# Altium schematic sheet MultiCharge2.SchDoc
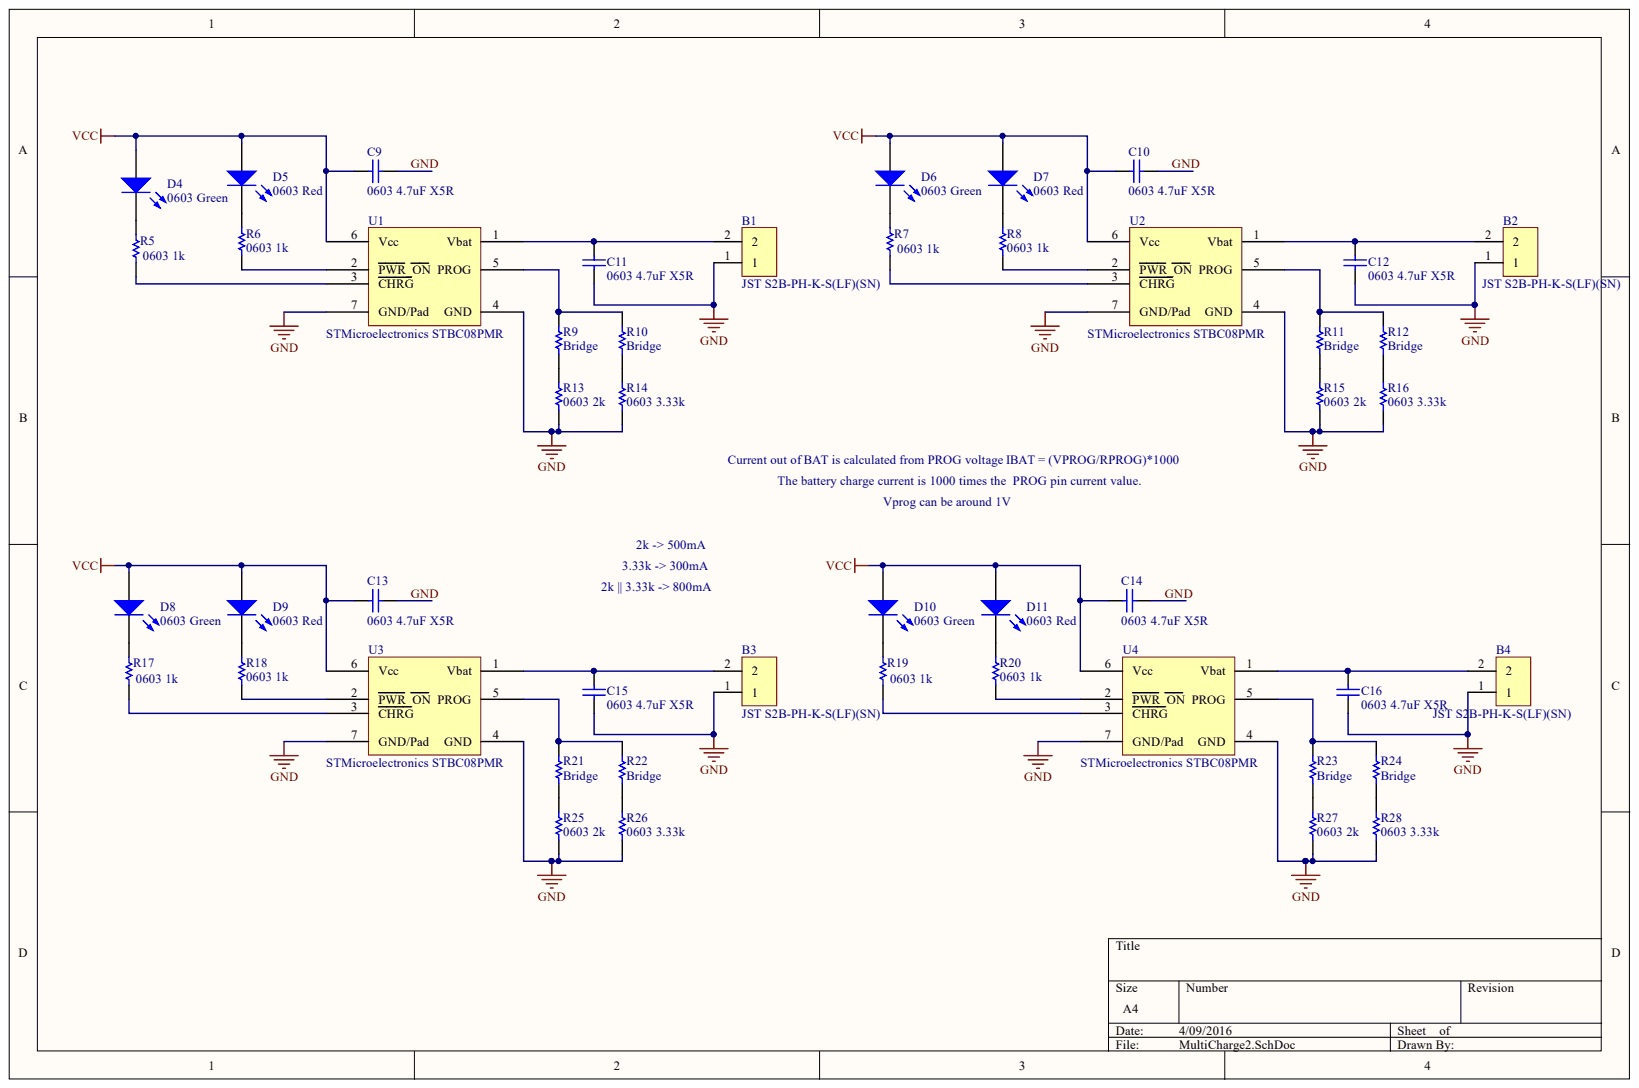
[diagram p0: MultiCharge2.SchDoc]

## Parts
B1  JST S2B-PH-K-S(LF)(SN)  [JST S2B-PH-K-S]
B2  JST S2B-PH-K-S(LF)(SN)  [JST S2B-PH-K-S]
B3  JST S2B-PH-K-S(LF)(SN)  [JST S2B-PH-K-S]
B4  JST S2B-PH-K-S(LF)(SN)  [JST S2B-PH-K-S]
C9  0603 4.7uF X5R  [CAPC1608X90X35ML10T15]
C10  0603 4.7uF X5R  [CAPC1608X90X35ML10T15]
C11  0603 4.7uF X5R  [CAPC1608X90X35ML10T15]
C12  0603 4.7uF X5R  [CAPC1608X90X35ML10T15]
C13  0603 4.7uF X5R  [CAPC1608X90X35ML10T15]
C14  0603 4.7uF X5R  [CAPC1608X90X35ML10T15]
C15  0603 4.7uF X5R  [CAPC1608X90X35ML10T15]
C16  0603 4.7uF X5R  [CAPC1608X90X35ML10T15]
D4  0603 Green  Case/Package: 0603  [LED1608x55N]
D5  0603 Red  Case/Package: 0603  [LED1608x55N]
D6  0603 Green  Case/Package: 0603  [LED1608x55N]
D7  0603 Red  Case/Package: 0603  [LED1608x55N]
D8  0603 Green  Case/Package: 0603  [LED1608x55N]
D9  0603 Red  Case/Package: 0603  [LED1608x55N]
D10  0603 Green  Case/Package: 0603  [LED1608x55N]
D11  0603 Red  Case/Package: 0603  [LED1608x55N]
R5  0603 1k  [RESC1608X55X30ML15T15]
R6  0603 1k  [RESC1608X55X30ML15T15]
R7  0603 1k  [RESC1608X55X30ML15T15]
R8  0603 1k  [RESC1608X55X30ML15T15]
R9  Bridge  [RESC1005X40X25NL05T05]
R10  Bridge  [RESC1005X40X25NL05T05]
R11  Bridge  [RESC1005X40X25NL05T05]
R12  Bridge  [RESC1005X40X25NL05T05]
R13  0603 2k  Case/Package: 0603  [RESC1609X50X30ML10T20]
R14  0603 3.33k  Case/Package: 0603  [RESC1608X55X30ML15T15]
R15  0603 2k  Case/Package: 0603  [RESC1609X50X30ML10T20]
R16  0603 3.33k  Case/Package: 0603  [RESC1608X55X30ML15T15]
R17  0603 1k  [RESC1608X55X30ML15T15]
R18  0603 1k  [RESC1608X55X30ML15T15]
R19  0603 1k  [RESC1608X55X30ML15T15]
R20  0603 1k  [RESC1608X55X30ML15T15]
R21  Bridge  [RESC1005X40X25NL05T05]
R22  Bridge  [RESC1005X40X25NL05T05]
R23  Bridge  [RESC1005X40X25NL05T05]
R24  Bridge  [RESC1005X40X25NL05T05]
R25  0603 2k  Case/Package: 0603  [RESC1609X50X30ML10T20]
R26  0603 3.33k  Case/Package: 0603  [RESC1608X55X30ML15T15]
R27  0603 2k  Case/Package: 0603  [RESC1609X50X30ML10T20]
R28  0603 3.33k  Case/Package: 0603  [RESC1608X55X30ML15T15]
U1  STMicroelectronics STBC08PMR  Manufacturer: STMicroelectronics  Manufacturer Part Number: STBC08PMR  [PCB-aislhniljlol1jo7ju5i-1]
U2  STMicroelectronics STBC08PMR  Manufacturer: STMicroelectronics  Manufacturer Part Number: STBC08PMR  [PCB-aislhniljlol1jo7ju5i-1]
U3  STMicroelectronics STBC08PMR  Manufacturer: STMicroelectronics  Manufacturer Part Number: STBC08PMR  [PCB-aislhniljlol1jo7ju5i-1]
U4  STMicroelectronics STBC08PMR  Manufacturer: STMicroelectronics  Manufacturer Part Number: STBC08PMR  [PCB-aislhniljlol1jo7ju5i-1]

## Nets
VCC: C9.2 C10.2 C13.2 C14.2 D4.1 D5.1 D6.1 D7.1 D8.1 D9.1 D10.1 D11.1 U1.6(Vcc) U2.6(Vcc) U3.6(Vcc) U4.6(Vcc)
GND: B1.1 B2.1 B3.1 B4.1 C9.1 C10.1 C11.1 C12.1 C13.1 C14.1 C15.1 C16.1 R13.1 R14.1 R15.1 R16.1 R25.1 R26.1 R27.1 R28.1 U1.4(GND) U1.7(GND/Pad) U2.4(GND) U2.7(GND/Pad) U3.4(GND) U3.7(GND/Pad) U4.4(GND) U4.7(GND/Pad)
NetB1_2: B1.2 C11.2 U1.1(Vbat)
NetB2_2: B2.2 C12.2 U2.1(Vbat)
NetB3_2: B3.2 C15.2 U3.1(Vbat)
NetB4_2: B4.2 C16.2 U4.1(Vbat)
NetD4_2: D4.2 R5.2
NetD5_2: D5.2 R6.2
NetD6_2: D6.2 R7.2
NetD7_2: D7.2 R8.2
NetD8_2: D8.2 R17.2
NetD9_2: D9.2 R18.2
NetD10_2: D10.2 R19.2
NetD11_2: D11.2 R20.2
NetR5_1: R5.1 U1.3(CHRG)
NetR6_1: R6.1 U1.2(PWR_ON)
NetR7_1: R7.1 U2.3(CHRG)
NetR8_1: R8.1 U2.2(PWR_ON)
NetR9_1: R9.1 R13.2
NetR9_2: R9.2 R10.2 U1.5(PROG)
NetR10_1: R10.1 R14.2
NetR11_1: R11.1 R15.2
NetR11_2: R11.2 R12.2 U2.5(PROG)
NetR12_1: R12.1 R16.2
NetR17_1: R17.1 U3.3(CHRG)
NetR18_1: R18.1 U3.2(PWR_ON)
NetR19_1: R19.1 U4.3(CHRG)
NetR20_1: R20.1 U4.2(PWR_ON)
NetR21_1: R21.1 R25.2
NetR21_2: R21.2 R22.2 U3.5(PROG)
NetR22_1: R22.1 R26.2
NetR23_1: R23.1 R27.2
NetR23_2: R23.2 R24.2 U4.5(PROG)
NetR24_1: R24.1 R28.2

## Library data of the parts
B1-B4 = CMP-642951-6: CONN HEADER PH SIDE 2POS 2MM; Gender: Male; Lead-Free Status: Lead Free; Number of Contacts: 2; Pin Pitch: 0.002 m; Component Kind: Standard; Contact Material: Brass; Contact Plating: Tin; Housing Color: Natural; Housing Material: Nylon; Library Name: CommunityVault; Mounting Style: Through Hole; Number of Positions: 2; Number of Rows: 1; OctopartID: 84064d0f6d535bc1; Octopart Url: https://octopart.com/s2b-ph-k-s%28lf%29%28sn%29-jst-248883; Packaging: Bulk; RoHS: Compliant; Size-Height: 0.0048 m; Size-Length: 0.0059 m; Size-Width: 0.0076 m
C9-C16 = CMP-9133140-3: Cap Ceramic 4.7uF 10V X5R 10% SMD 0603 85C Paper T/R; Capacitance: 4.7e-06 F; Case/Package (SI): 1608; Voltage Rating (DC): 10.0 V; Capacitance Tolerance: ±10%; Component Kind: Standard; Dielectric Characteristic: X5R; Library Name: CommunityVault; Lifecycle Status: Active; OctopartID: f5ad3de176fc2a6a; Octopart Url: https://octopart.com/grm188r61a475ke15d-murata-21407287; Packaging: Cut Tape (CT); REACH SVHC Compliance: No SVHC; RoHS: Compliant; Size-Length: 0.0016 m; Size-Thickness: 0.0008 m; Size-Width: 0.0008 m
D4 D6 D8 D10 = CMP-1544853-3: Green 1.6 x 0.8 x 0.8 mm 130° Water Clear 6 mcd 2.1 V SMT LED; Manufacturer Part Number: LTST-C190GKT; Forward Voltage: 2.1 V; Lead-Free Status: Lead Free; Lens Type: Non-Diffused; Luminous Intensity: 0.006 cd; Wavelength: 5.65e-07 m; Color: Green; Component Kind: Standard; Library Name: CommunityVault; Mounting Style: Surface Mount, Vertical; OctopartID: b2f07bf2f42b6d37; Octopart URL: http://octopart.com/ltst-c190gkt-lite-on-549821; Packaging: Tape & Reel (TR); RoHS: Compliant; Size-Height: 0.0008 m; Size-Length: 0.0016 m; Size-Width: 0.0008 m; Viewing Angle: 130 °
D5 D7 D9 D11 = CMP-1544848-3: LED SUPER RED CLR THIN 0603 SMD; Forward Voltage: 2.0 V; Lead-Free Status: Lead Free; Lens Type: Non-Diffused; Luminous Intensity: 0.054 cd; Viewing Angle: 130 °; Wavelength: 6.39e-07 m; Color: Red; Component Kind: Standard; Library Name: CommunityVault; OctopartID: 4ddf84dd0cad9218; Octopart Url: https://octopart.com/ltst-c191krkt-lite-on-549908; RoHS: Compliant; Size-Height: 0.00055 m; Size-Length: 0.0016 m; Size-Width: 0.0008 m
R5-R8 R17-R20 = CMP-3654795-5: Precision resistor, SMD [Panasonic Automotive & Industrial Systems] ERJ3EKF1001V Precision resistor, SMD; Manufacturer Part Number: ERJ-3EKF1001V; Power Rating: 100 mW; Resistance Tolerance: ±1%; Component Kind: Standard; Library Name: CommunityVault; Number of Pins: 2; Octopart URL: http://octopart.com/erj-3ekf1001v-panasonic-55403646; Size-Height: 450 µm
R9-R12 R21-R24 = CMP-15792799-5: Bridge resistor 0ohm; Lead-Free Status: Lead Free; Power Rating: 0.063 W; Resistance: 0.0 Ω; Resistance Tolerance: ±1%; Voltage Rating (DC): 50.0 V; Case/Package: 0402; Component Kind: Standard; Library Name: CommunityVault; Mounting Style: Surface Mount; Number of Pins: 2; OctopartID: fdb336e0639f2274; Octopart Url: https://octopart.com/crcw04020000z0ed-vishay-39435082; REACH SVHC Compliance: No SVHC; RoHS: Compliant; Size-Height: 0.00035 m; Size-Length: 0.001 m; Size-Width: 0.0005 m
R13 R15 R25 R27 = CMP-1877180-2: RES SMD 2K OHM 5% 1/10W 0603; Lead-Free Status: Lead Free; Power Rating: 0.1 W; Resistance: 2000.0 Ω; Temperature Coefficient: 200.0 ppm/°C; Voltage Rating (DC): 75.0 V; Component Kind: Standard; Library Name: CommunityVault; Mounting Style: Surface Mount; Number of Pins: 2; OctopartID: d88b13de5f6d074c; Octopart Url: https://octopart.com/crcw06032k00jnea-vishay-39821952; Resistance Tolerance: ±5%; RoHS: Compliant; Size-Height: 0.00045 m; Size-Length: 0.00155 m; Size-Width: 0.00085 m
R14 R16 R26 R28 = CMP-3656259-2: Thick Film Resistors - SMD 0603 3.3Kohms 5% Tol; Lead-Free Status: Lead Free; Power Rating: 0.1 W; Resistance: 3300.0 Ω; Voltage Rating (DC): 75.0 V; Component Kind: Standard; Library Name: CommunityVault; Lifecycle Status: Not Listed by Manufacturer; Mounting Style: Surface Mount; OctopartID: f2c038877b272d07; Octopart Url: https://octopart.com/erj-3geyj332v-panasonic-55422081; Packaging: Cut Tape (CT); REACH SVHC Compliance: No SVHC; Resistance Tolerance: ±5%; RoHS: Compliant; Size-Height: 0.00045 m; Size-Length: 0.0016 m; Size-Width: 0.0008 m
U1-U4 = CMP-facc33d44885250b-1: IC BATT CHRGR LI-ION 4.2V 800MA DFN6; Manufacturer Part Number, Other: 497-5808-1; Vendor Part Number: 497-5808-1-ND; Function: Charge Management; Voltage - Supply: 4.25 V ~ 6.5 V; Battery Chemistry: Li-Ion; Category: Integrated Circuits (ICs); Component Kind: Standard; Family: PMIC - Battery Management; HelpURL: http://www2.st.com/content/ccc/resource/technical/document/datasheet/32/cd/28/84/1b/53/4e/7d/CD00132950.pdf/files/CD00132950.pdf/jcr:content/translations/en.CD00132950.pdf; Library Name: CommunityVault; Mounting Type: Surface Mount; OctopartID: facc33d44885250b; Octopart URL: http://octopart.com/stbc08pmr-stmicroelectronics-1218351; Operating Temperature: -40°C ~ 85°C; Package / Case: 6-VDFN Exposed Pad; Price: 1.20; Supplier Device Package: 6-DFN (3x3)
